# Altium schematic sheet ESC.SchDoc(ESC)
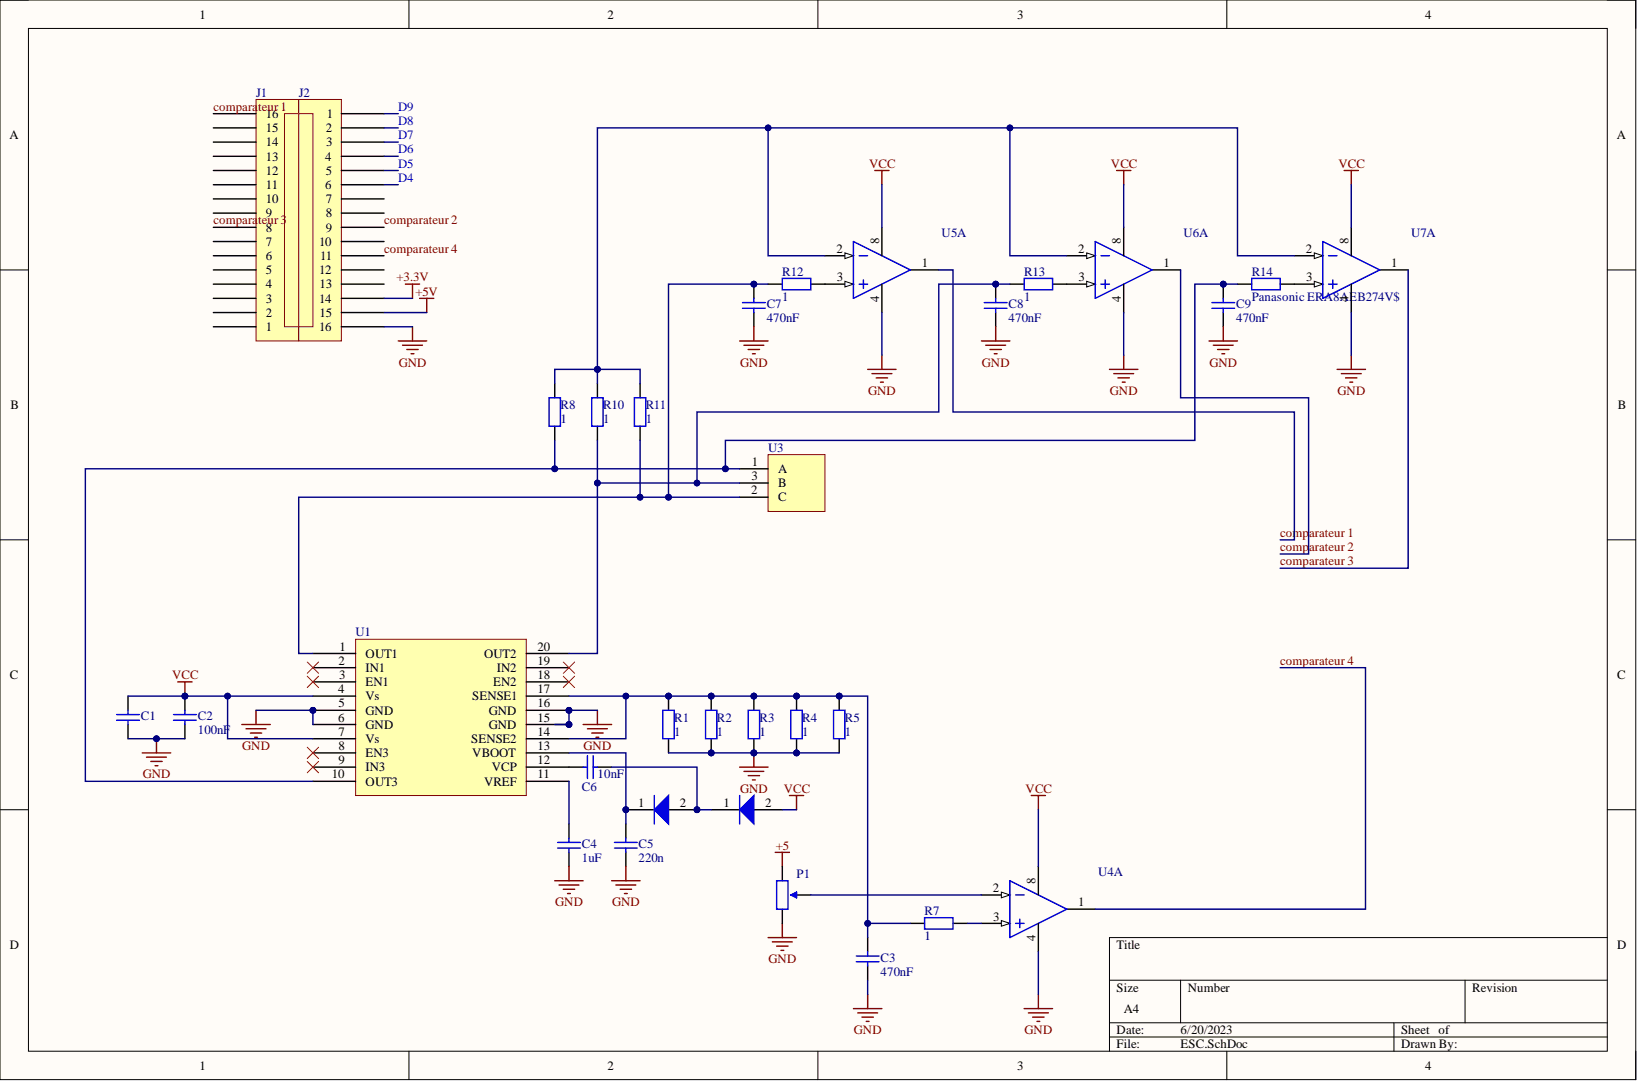
[diagram p0: ESC.SchDoc]

## Parts
C1  Kemet C1206C471J5GAC7800  (value not drawn)
C2  100nF
C3  470nF
C4  1uF
C5  220n
C6  10nF
C7  470nF
C8  470nF
C9  470nF
D1  Bourns CD1206-S01575  [PCB-93eesnz9fqft5tg3zumo-1]  (value not drawn)
D2  Bourns CD1206-S01575  [PCB-93eesnz9fqft5tg3zumo-1]  (value not drawn)
J1  TE Connectivity 1-640456-6  [PCB-345877-1]  (value not drawn)
J2  TE Connectivity 1-640456-6  [PCB-345877-1]  (value not drawn)
P1  BI Technologies 67YR5KLF  [PCB-dqoeucvojecij4i84qyl-1]  (value not drawn)
R1  1
R2  1
R3  1
R4  1
R5  1
R7  1
R8  1
R10  1
R11  1
R12  1
R13  1
R14  Panasonic ERA8AEB274V$
U1  STMicroelectronics L6234  [PCB-riuc6u2llk7cankzansq-1]  (value not drawn)
U3  ALPS EC12E24204A8  [PCB-rh7x9t79pmlpylfnmnn3-1]  (value not drawn)
U4  Fairchild Semiconductor LM393AN  (value not drawn)  (part A)
U5  Fairchild Semiconductor LM393AN  (value not drawn)  (part A)
U6  Fairchild Semiconductor LM393AN  (value not drawn)  (part A)
U7  Fairchild Semiconductor LM393AN  (value not drawn)  (part A)

## Nets
+3.3V: J2.14
+5: P1.1
+5V: J2.15
GND: C1.1 C2.1 C3.1 C4.1 C5.1 C7.1 C8.1 C9.1 J2.16 P1.3 R1.1 R2.1 R3.1 R4.1 R5.1 U1.5(GND) U1.6(GND) U1.15(GND) U1.16(GND) U4.4 U5.4 U6.4 U7.4
VCC: C1.2 C2.2 D2.2 U1.4(Vs) U1.7(Vs) U4.8 U5.8 U6.8 U7.8
comparateur 1: J1.16 U5.1
comparateur 2: J2.9 U6.1
comparateur 3: J1.8 U7.1
comparateur 4: J2.11 U4.1
D4: J2.6
D5: J2.5
D6: J2.4
D7: J2.3
D8: J2.2
D9: J2.1
NetC3_2: C3.2 R1.2 R2.2 R3.2 R4.2 R5.2 R7.2 U1.14(SENSE2) U1.17(SENSE1)
NetC4_2: C4.2 U1.11(VREF)
NetC5_2: C5.2 D1.1 U1.13(VBOOT)
NetC6_1: C6.1 D1.2 D2.1
NetC6_2: C6.2 U1.12(VCP)
NetC7_2: C7.2 R11.1 R12.2 U1.1(OUT1) U3.2
NetC8_2: C8.2 R10.1 R13.2 U1.20(OUT2) U3.3
NetC9_2: C9.2 R8.1 R14.2 U1.10(OUT3) U3.1
NetP1_2: P1.2 U4.2
NetR7_1: R7.1 U4.3
NetR8_2: R8.2 R10.2 R11.2 U5.2 U6.2 U7.2
NetR12_1: R12.1 U5.3
NetR13_1: R13.1 U6.3
NetR14_1: R14.1 U7.3

Not connected: J1 1 2 3 4 5 6 7 9 10 11 12 13 14 15; J2 7 8 10 12 13; U1 2(IN1) 3(EN1) 8(EN3) 9(IN3) 18(EN2) 19(IN2)

## Library data of the parts
C1-C9 = CMP-8752282-2: Cap Ceramic 470pF 50V C0G 5% SMD 1206 125C Plastic T/R; Manufacturer Part Number: C1206C471J5GACTU; Capacitance: 4.7e-10 F; Lead-Free Status: Lead Free; Voltage Rating (DC): 50.0 V; Capacitance Tolerance: ±5%; Case/Package: 1206; Component Kind: Standard; Dielectric Material: Ceramic; Library Name: My Personal Space; Mounting Style: Surface Mount; Octopart Url: https://octopart.com/c1206c471j5gac7800-kemet-3915253; OctopartID: 8a66435712f555a1; RoHS: Compliant
D1 D2 = CMP-1021910-11: Diode Small Signal Switching 100V 0.15A 2-Pin Case 1206(3216Metric) T/R; Case/Package (SI): 3216; Forward Voltage: 1.00 V; Case/Package: 1206; Component Kind: Standard; Library Name: My Personal Space; Manufacturer Part Number: CD1206-S01575; Mounting Style: Surface Mount; Number of Pins: 2; Octopart URL: https://octopart.com/cd1206-s01575-bourns-709948; OctopartID: d7cc1ecc2796080b; Operating Temperature: -55.0 °C to 125 °C; Packaging: Reel; REACH SVHC Compliance: No SVHC; RoHS: Compliant
J1 J2 = CMP-5cb5977adcc98372-4: Header, Friction Lock; MTA-100; 16; 250 VAC; Polyester; Tin; Copper Alloy; Tin; ELV Compliant: Compliant; Gender: Male; Lead-Free Status: Lead Free; Mounting Angle: 180 °; Number of Contacts: 16; Pin Pitch: 2.54 mm; Shrouded: No; Voltage Rating (AC): 250 V; Color: White; Component Kind: Standard; Contact Material: Copper Alloy; Contact Plating: Tin; Flammability Rating: UL 94 V-0; Housing Color: White; Housing Material: Polyester; Library Name: My Personal Space; Lifecycle Status: Active; Manufacturer Part Number: 1-640456-6; Mounting Style: Through Hole, Vertical; Number of Positions: 16; Number of Rows: 1; Octopart URL: https://octopart.com/1-640456-6-te+connectivity-46507290; OctopartID: 5cb5977adcc98372; Operating Temperature: 105 °C (max); Orientation: Vertical; Packaging: Bag; REACH SVHC Compliance: No SVHC; RoHS: Compliant; Size-Height: 7.87 mm; Size-Length: 40.6 mm; Size-Width: 5.71 mm; Voltage Rating (DC): 250 V
P1 = CMP-52249b0eae068de3-1: Res Cermet Trimmer 5K Ohm 10% 0.5W 20(Elec)Turn 2.29mm (9.53 X 4.95 X 11.98mm) Pin Thru-Hole Box; Lead-Free Status: Lead Free; Power Rating: 500 mW; Resistance: 5.00 kΩ; Temperature Coefficient: -100 ppm/°C to 100 ppm/°C; Voltage Rating (DC): 200 V; Component Kind: Standard; Library Name: My Personal Space; Manufacturer Part Number: 67YR5KLF; Mounting Style: Through Hole; Octopart URL: https://octopart.com/67yr5klf-bi+technologies-2152772; OctopartID: 52249b0eae068de3; Packaging: Bag; Resistance Tolerance: ±10%; RoHS: Compliant
R1-R5 R7 R8 R10-R14 = CMP-1892449-3: Res Metal Film 1206 270 Ohm 0.1% 1/4W; Lead-Free Status: Lead Free; Power Rating: 0.25 W; Res Metal Film 1206 1/4W: 1206; Resistance: 270.0 Ω; Voltage Rating (DC): 150.0 V; Component Kind: Standard; Library Name: My Personal Space; Mounting Style: Surface Mount; OctopartID: bed1768c4c586116; REACH SVHC Compliance: No SVHC; Resistance Tolerance: ±0.1%; RoHS: Compliant
U1 = CMP-d5761c7d53450ab1-1: 3-Phase Brushless DC Motor Controller 42V Automotive 20-Pin Power PDIP Tube; Lead-Free Status: Lead Free; Number of Outputs: 3; Output Current: 5.00 A; Output Voltage: 52.0 V; Supply Current: 7.00 mA; Supply Voltage (DC): 7.00 V (min), 42.0 V (max); Case/Package: PDIP; Component Kind: Standard; Library Name: My Personal Space; Lifecycle Status: Active; Manufacturer Part Number: L6234; Mounting Style: Through Hole; Number of Pins: 20; Octopart URL: https://octopart.com/l6234-stmicroelectronics-107349; OctopartID: d5761c7d53450ab1; Operating Temperature: 0.00 °C to 150 °C; Packaging: Tube; REACH SVHC Compliance: No SVHC; RoHS: Compliant
U3 = CMP-1676df77bddbc9b1-3: Mechanical Encoder Rotary Incremental Flat 20mN.m Straight Quadrature Digital Square Wave 24PPR Through Hole PC Pin; Lead-Free Status: Lead Free; Number of Detents: 24; Supply Voltage (DC): 5.00 V (max); Component Kind: Standard; Library Name: My Personal Space; Manufacturer Part Number: EC12E24204A8; Mounting Style: Through Hole; Octopart URL: https://octopart.com/ec12e24204a8-alps-757451; OctopartID: 1676df77bddbc9b1; Packaging: Tray; REACH SVHC Compliance: No SVHC; RoHS: Compliant
U4-U7 = CMP-520a9b06fc638dcd-2: LM393 Series Very Low Supply Current Low Power Dual Voltage Comparator - DIP8; Differential Input Voltage: 36 V; Input Voltage: -0.3V to 36V; Input Voltage Offset: ±1mV (±2mV max); Lead-Free Status: Lead Free; Number of Channels: 4; Number of Circuits: 2; Output Current: 18 mA @ 5 V; Quiescent Current: 800 μA; Supply Voltage (DC): 2V to 36V, ±1V to ±18V; Case/Package: DIP; Component Kind: Standard; Library Name: My Personal Space; Lifecycle Status: Active; Manufacturer: Fairchild Semiconductor; Manufacturer Part Number: LM393AN; Mounting Style: Through Hole; Number of Pins: 8; Octopart URL: http://octopart.com/lm393an-fairchild+semiconductor-428815; OctopartID: 520a9b06fc638dcd; Operating Temperature: 0C to 70C; Packaging: Tube; REACH SVHC Compliance: No SVHC; RoHS: Compliant; Supply Current: 800 μA
